# Altium schematic sheet MCU_64.SchDoc
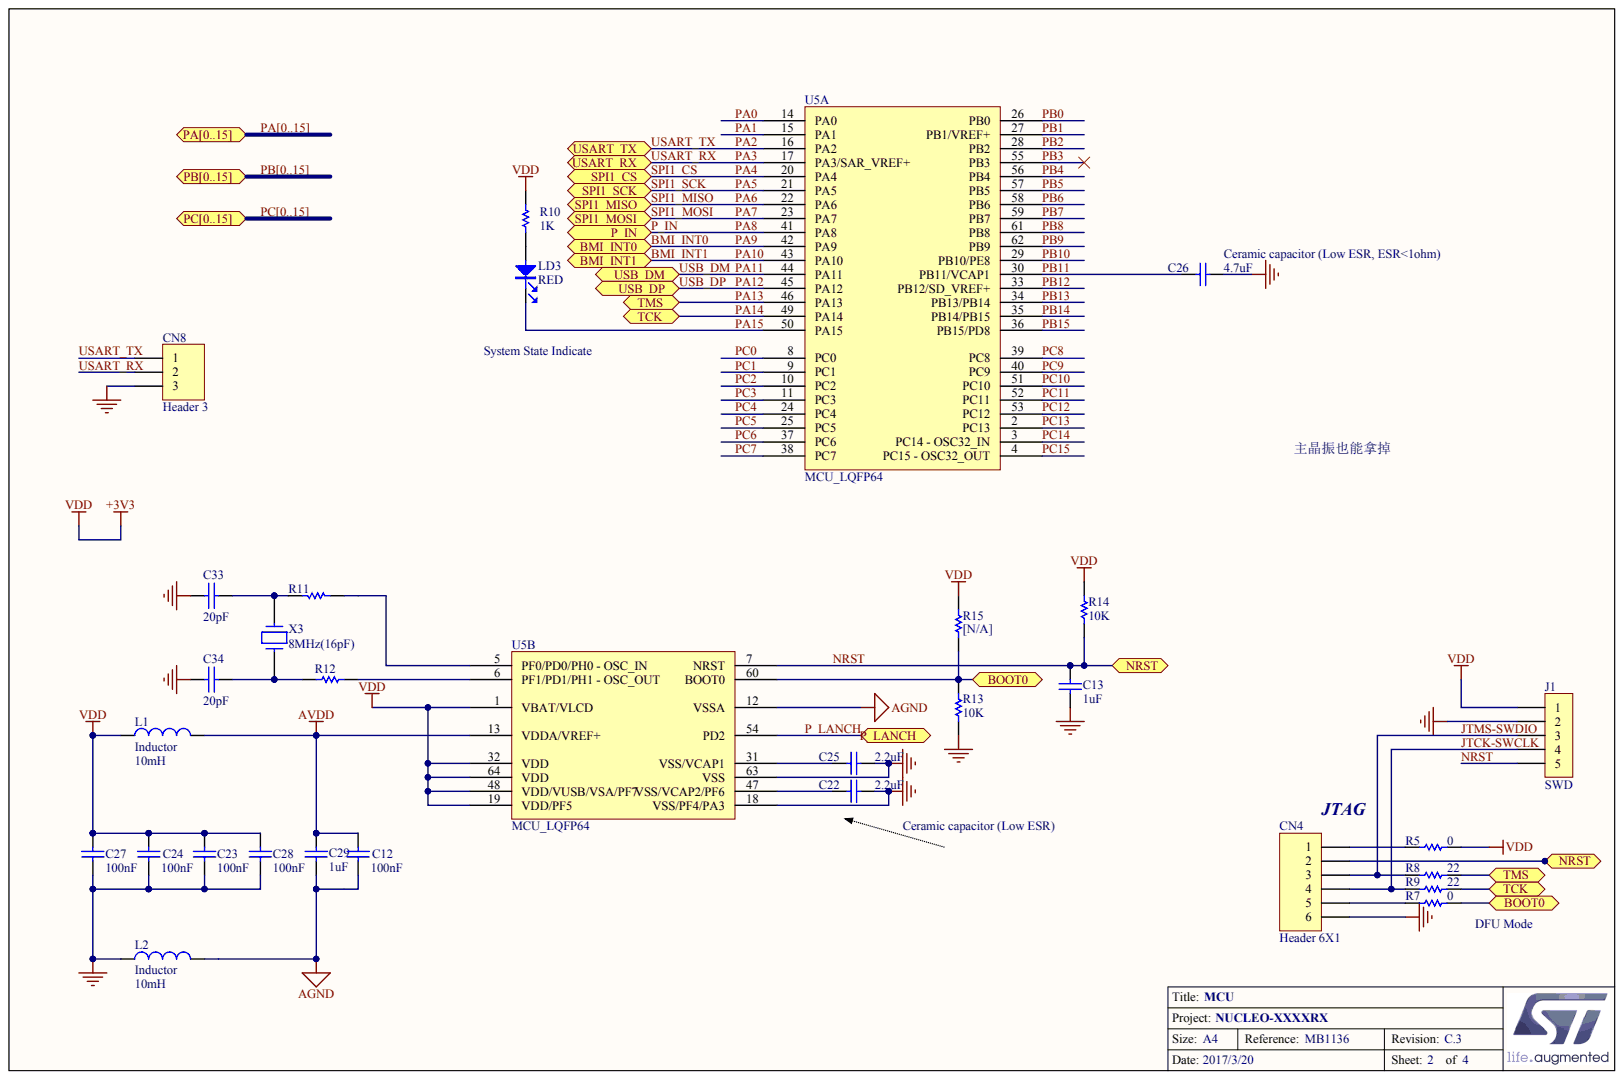
[diagram p0: MCU_64.SchDoc]

## Parts
C12  100nF
C13  1uF
C22  2.2uF
C23  100nF
C24  100nF
C25  2.2uF
C26  4.7uF
C27  100nF
C28  100nF
C29  1uF
C33  20pF
C34  20pF
CN4  Header 6X1
CN8  Header 3
J1  SWD
L1  Inductor  value 10mH
L2  Inductor  value 10mH
LD3  RED
R5  0
R7  0
R8  22
R9  22
R10  1K
R11  (no value)
R12  (no value)
R13  10K
R14  10K
R15  [N/A]
U5  MCU_LQFP64  (parts A,B)
X3  8MHz(16pF)

## Ports
BMI_INT0, BMI_INT1, BOOT0, NRST, P_IN, P_LANCH, PA0, PA1, PA2, PA3, PA4, PA5, PA6, PA7, PA8, PA9, PA10, PA11, PA12, PA13, PA14, PA15, PA[0..15], PB0, PB1, PB2, PB3, PB4, PB5, PB6, PB7, PB8, PB9, PB10, PB11, PB12, PB13, PB14, PB15, PB[0..15], PC0, PC1, PC2, PC3, PC4, PC5, PC6, PC7, PC8, PC9, PC10, PC11, PC12, PC13, PC14, PC15, PC[0..15], SPI1_CS, SPI1_MISO, SPI1_MOSI, SPI1_SCK, TCK, TMS, USART_RX, USART_TX, USB_DM, USB_DP

## Nets
+3V3: C23.1 C24.1 C27.1 C28.1 J1.1 L1.1 R5.2 R10.2 R14.1 R15.1 U5.1(VBAT/VLCD) U5.19(VDD/PF5) U5.32(VDD) U5.48(VDD/VUSB/VSA/PF7) U5.64(VDD)
AGND: C12.2 C29.2 L2.2 U5.12(VSSA)
AVDD: C12.1 C29.1 L1.2 U5.13(VDDA/VREF+)
GND: C13.2 C22.2 C23.2 C24.2 C25.2 C26.2 C27.2 C28.2 C33.2 C34.2 CN4.6 CN8.3 J1.2 L2.1 R13.2 U5.18(VSS/PF4/PA3) U5.63(VSS)
BOOT0: R7.2 R13.1 R15.2 U5.60(BOOT0) ; port BOOT0
JTCK-SWCLK: CN4.4 J1.4 R9.1
JTMS-SWDIO: CN4.3 J1.3 R8.1
NRST: C13.1 CN4.2 J1.5 R14.2 U5.7(NRST) ; port NRST
P_LANCH: U5.54(PD2) ; port P_LANCH
PA0: U5.14(PA0) ; port PA[0..15]  [also labelled PA]
PA1: U5.15(PA1) ; port PA[0..15]  [also labelled PA]
PA2: CN8.1 U5.16(PA2) ; port PA[0..15], port USART_TX  [also labelled PA]
PA3: CN8.2 U5.17(PA3/SAR_VREF+) ; port PA[0..15], port USART_RX  [also labelled PA]
PA4: U5.20(PA4) ; port PA[0..15], port SPI1_CS  [also labelled PA]
PA5: U5.21(PA5) ; port PA[0..15], port SPI1_SCK  [also labelled PA]
PA6: U5.22(PA6) ; port PA[0..15], port SPI1_MISO  [also labelled PA]
PA7: U5.23(PA7) ; port PA[0..15], port SPI1_MOSI  [also labelled PA]
PA11: U5.44(PA11) ; port PA[0..15], port USB_DM  [also labelled PA]
PA12: U5.45(PA12) ; port PA[0..15], port USB_DP  [also labelled PA]
PA13: R8.2 U5.46(PA13) ; port PA[0..15], port TMS  [also labelled PA]
PA14: R9.2 U5.49(PA14) ; port PA[0..15], port TCK  [also labelled PA]
PA15: LD3.2 U5.50(PA15) ; port PA[0..15]  [also labelled PA]
PB0: U5.26(PB0) ; port PB[0..15]  [also labelled PB]
PB1: U5.27(PB1/VREF+) ; port PB[0..15]  [also labelled PB]
PB2: U5.28(PB2) ; port PB[0..15]  [also labelled PB]
PB3: U5.55(PB3) ; port PB[0..15]  [also labelled PB]
PB4: U5.56(PB4) ; port PB[0..15]  [also labelled PB]
PB5: U5.57(PB5) ; port PB[0..15]  [also labelled PB]
PB6: U5.58(PB6) ; port PB[0..15]  [also labelled PB]
PB7: U5.59(PB7) ; port PB[0..15]  [also labelled PB]
PB8: U5.61(PB8) ; port PB[0..15]  [also labelled PB]
PB9: U5.62(PB9) ; port PB[0..15]  [also labelled PB]
PB10: U5.29(PB10/PE8) ; port PB[0..15]  [also labelled PB]
PB11: C26.1 U5.30(PB11/VCAP1) ; port PB[0..15]  [also labelled PB]
PB12: U5.33(PB12/SD_VREF+) ; port PB[0..15]  [also labelled PB]
PB13: U5.34(PB13/PB14) ; port PB[0..15]  [also labelled PB]
PB14: U5.35(PB14/PB15) ; port PB[0..15]  [also labelled PB]
PB15: U5.36(PB15/PD8) ; port PB[0..15]  [also labelled PB]
PC0: U5.8(PC0) ; port PC[0..15]  [also labelled PC]
PC1: U5.9(PC1) ; port PC[0..15]  [also labelled PC]
PC2: U5.10(PC2) ; port PC[0..15]  [also labelled PC]
PC3: U5.11(PC3) ; port PC[0..15]  [also labelled PC]
PC4: U5.24(PC4) ; port PC[0..15]  [also labelled PC]
PC5: U5.25(PC5) ; port PC[0..15]  [also labelled PC]
PC6: U5.37(PC6) ; port PC[0..15]  [also labelled PC]
PC7: U5.38(PC7) ; port PC[0..15]  [also labelled PC]
PC8: U5.39(PC8) ; port PC[0..15]  [also labelled PC]
PC9: U5.40(PC9) ; port PC[0..15]  [also labelled PC]
PC10: U5.51(PC10) ; port PC[0..15]  [also labelled PC]
PC11: U5.52(PC11) ; port PC[0..15]  [also labelled PC]
PC12: U5.53(PC12) ; port PC[0..15]  [also labelled PC]
PC13: U5.2(PC13) ; port PC[0..15]  [also labelled PC]
PC14: U5.3(PC14_-_OSC32_IN) ; port PC[0..15]  [also labelled PC]
PC15: U5.4 ; port PC[0..15]  [also labelled PC]
NetC22_1: C22.1 U5.47(VSS/VCAP2/PF6)
NetC25_1: C25.1 U5.31(VSS/VCAP1)
NetC33_1: C33.1 R11.2 X3.2
NetC34_1: C34.1 R12.2 X3.1
NetCN4_1: CN4.1 R5.1
NetCN4_5: CN4.5 R7.1
NetLD3_1: LD3.1 R10.1
NetR11_1: R11.1 U5.5
NetR12_1: R12.1 U5.6

Not connected: U5 41(PA8) 42(PA9) 43(PA10)

## Library data of the parts
C12 C23 C24 C27 C28 = (no symbol name): Value: 10nF; Published: 8-Jun-2000; Revision: July-2002: Re-released for DXP Platform.; Publisher: Altium Limited
C13 C29 = (no symbol name): Value: 1µF; Rated voltage: 25V; Tolerance: 10%; Manufacturer: *; Manufacturer P/N: *; Order code Farnell: 1288202RL; Power: *; Technology: X5R; Temperature  Factor: *; Temperature Max: +125°C; Temperature Min: -55°C; STS Code: *
C22 C25 = (no symbol name): Manuf_Part_1: CC0805KKX7R7BB225; Manuf_1: YAGEO; Revision: July-2002: Re-released for DXP Platform.; Value: 2.2uF; Order: CC0805KKX7R7BB225
C26 = (no symbol name): Manuf_Part_1: CC0805KKX7R7BB225; Manuf_1: YAGEO; Value: 2.2uF; Revision: July-2002: Re-released for DXP Platform.; Order: CC0805KKX7R7BB225
L1 L2 = (no symbol name): Code_IEC: 1005; Published: 8-Jun-2000; LatestRevisionDate: 29-May-2009; LatestRevisionNote: IPC-7351 Footprint Added.; PackageReference: 0402-A; PackageDescription: Chip Inductor; Code_JEITA: 0402; Publisher: Altium Limited
R5 R7-R10 = (no symbol name): Value: 10K
R11-R15 = (no symbol name): Value: 1K; Published: 8-Jun-2000; Revision: July-2002: Re-released for DXP Platform.; Publisher: Altium Limited
C33 C34 CN4 CN8 J1 LD3 U5 X3 = (no symbol name)
  CN4 CN8: LatestRevisionDate: 17-Jul-2002; LatestRevisionNote: Re-released for DXP Platform.; Publisher: Altium Limited
